# Altium schematic sheet Sheet1.SchDoc
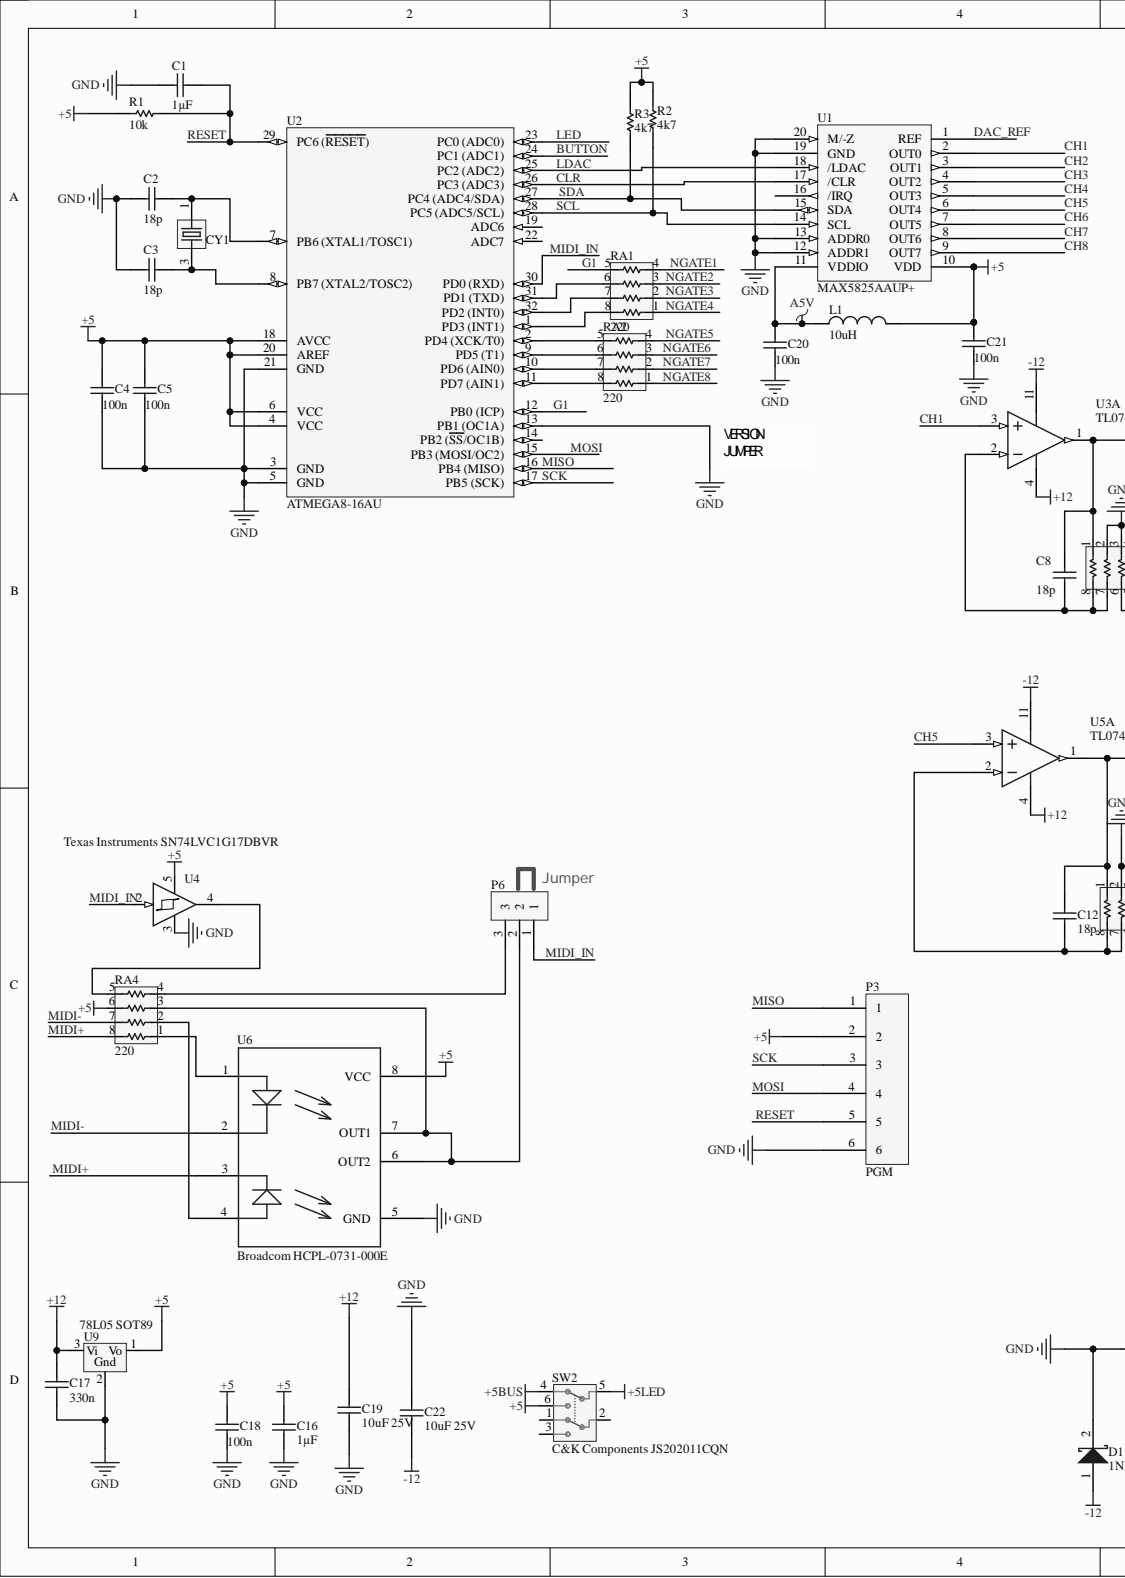
[diagram p0: Sheet1.SchDoc, left]
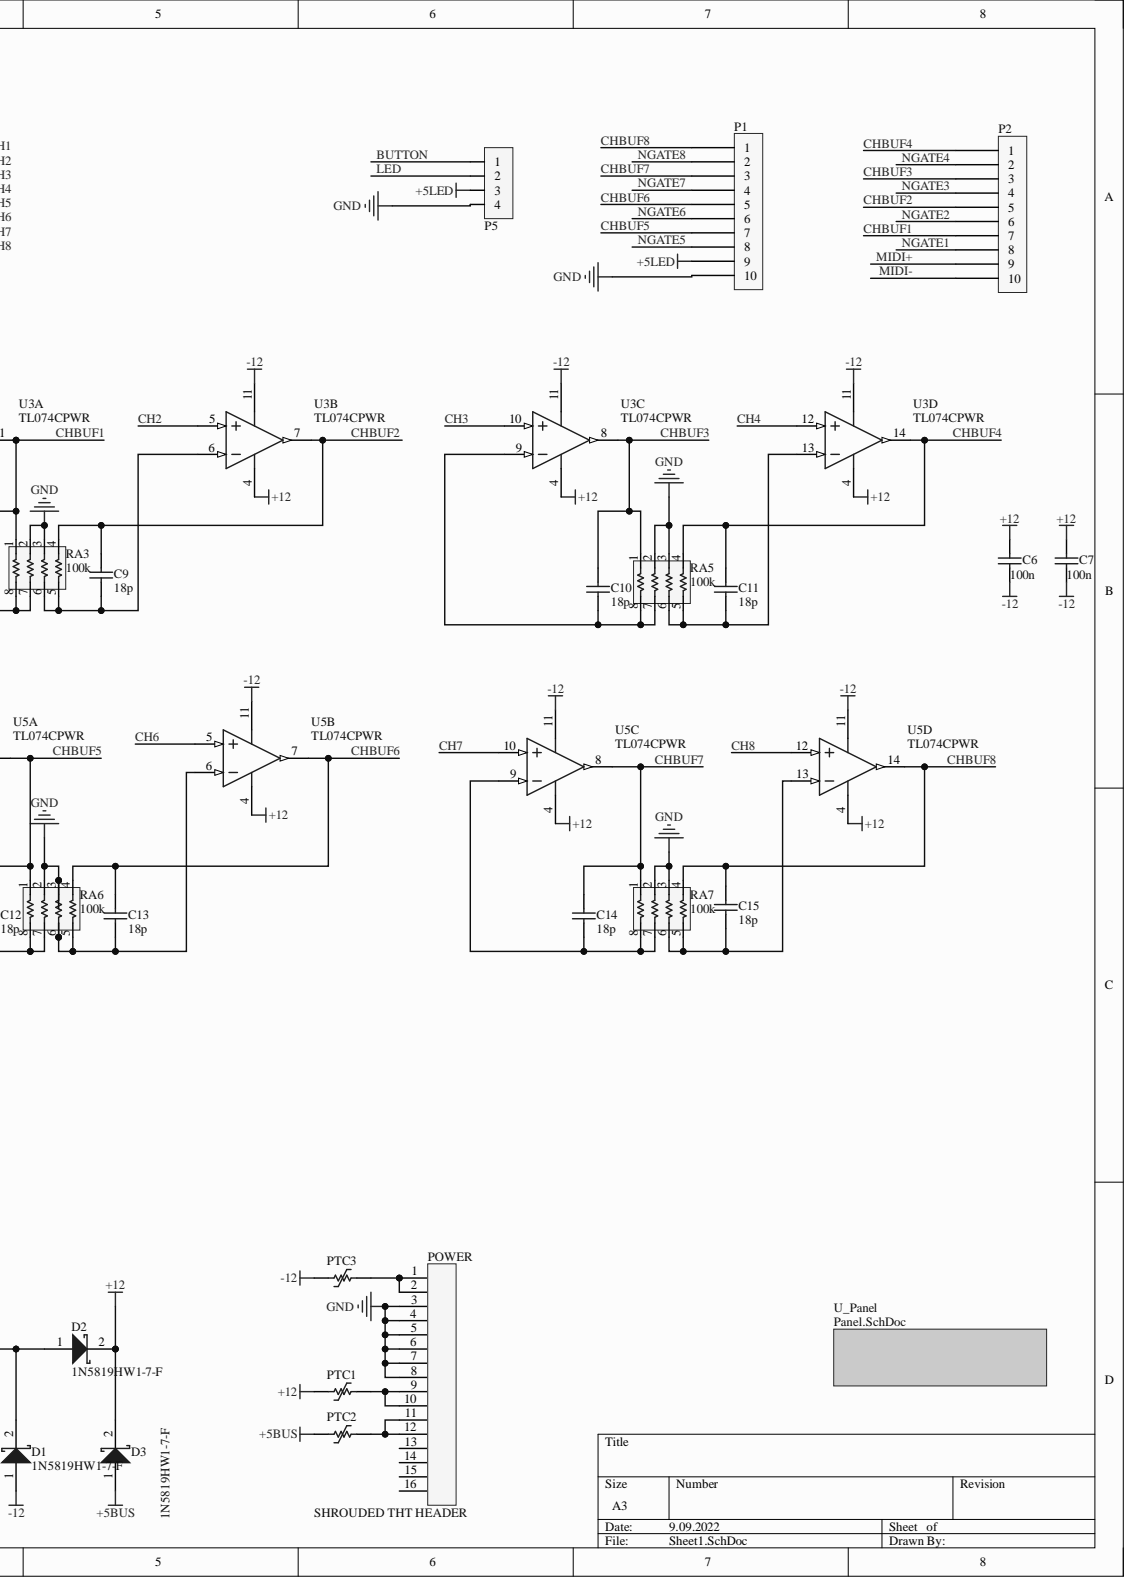
[diagram p1: Sheet1.SchDoc, right]

## Parts
C1  1µF  [CAPC1608X90X35ML10T15]
C2  18p  [CAPC1608X90X35ML10T15]
C3  18p  [CAPC1608X90X35ML10T15]
C4  100n  [CAPC1608X90X35ML10T15]
C5  100n  [CAPC1608X90X35ML10T15]
C6  100n  [CAPC1608X90X35ML10T15]
C7  100n  [CAPC1608X90X35ML10T15]
C8  18p  [CAPC1608X90X35ML10T15]
C9  18p  [CAPC1608X90X35ML10T15]
C10  18p  [CAPC1608X90X35ML10T15]
C11  18p  [CAPC1608X90X35ML10T15]
C12  18p  [CAPC1608X90X35ML10T15]
C13  18p  [CAPC1608X90X35ML10T15]
C14  18p  [CAPC1608X90X35ML10T15]
C15  18p  [CAPC1608X90X35ML10T15]
C16  1µF  [CAPC1608X90X35ML10T15]
C17  330n  [CAPC1608X90X35ML10T15]
C18  100n  [CAPC1608X90X35ML10T15]
C19  10uF 25V  [PCB-0002-00482-3]
C20  100n  [CAPC1608X90X35ML10T15]
C21  100n  [CAPC1608X90X35ML10T15]
C22  10uF 25V  [PCB-0002-00482-3]
CY1  Abracon ABM8G-16.000MHZ-4Y-T3  [PCB-twm83f3okejsqp61kejt-1]  (value not drawn)
D1  1N5819HW1-7-F  [SOD123F]
D2  1N5819HW1-7-F  [SOD123F]
D3  1N5819HW1-7-F  [SOD123F]
L1  10uH  [PCB-sg46dcudmto2bbepxwmm-1]
P1  TE Connectivity / AMP 1-87224-0  [PCB-cp1yv2tkluzxbj61re20-1]  (value not drawn)
P2  TE Connectivity / AMP 1-87224-0  [PCB-cp1yv2tkluzxbj61re20-1]  (value not drawn)
P3  PGM  [PCB-bqk69edvkj14uqp43gk0-1]
P5  FCI 68001-104HLF  [PCB-90ku6kdjhfi8i1gkzcxx-1]  (value not drawn)
P6  TE Connectivity 826629-3  [PCB-hdyvs4k31jnr0l9k2ks5-1]  (value not drawn)
POWER  SHROUDED THT HEADER  [PCB-k58dymiutsbg6tn8hs5t-1]
PTC1  PTC FUSE  [PCB-g6xbbgrf1j6f6hdcs2hx-1]  (value not drawn)
PTC2  PTC FUSE  [PCB-g6xbbgrf1j6f6hdcs2hx-1]  (value not drawn)
PTC3  PTC FUSE  [PCB-g6xbbgrf1j6f6hdcs2hx-1]  (value not drawn)
R1  10k  [RESC1609X55X30ML10T20]
R2  4k7  [RESC1609X55X30ML10T20]
R3  4k7  [RESC1609X55X30ML10T20]
RA1  220  [BOUR-CAY16-J4_V]
RA2  220  [BOUR-CAY16-J4_V]
RA3  100k  [BOUR-CAY16-J4_V]
RA4  220  [BOUR-CAY16-J4_V]
RA5  100k  [BOUR-CAY16-J4_V]
RA6  100k  [BOUR-CAY16-J4_V]
RA7  100k  [BOUR-CAY16-J4_V]
SW2  C&K Components JS202011CQN  [PCB-iaw2ery1xcz1khkintlh-1]
U1  MAX5825AAUP+  [TSOP65P640X110-20N]
U2  ATMEGA8-16AU  [PCB-yj7dx8a2gm3oo8law51k-1]
U3  TL074CPWR  [TI-PW14_L]  (parts A,B,C,D)
U4  Texas Instruments SN74LVC1G17DBVR  Manufacturer Part Number: SN74LVC1G17DBVR  [PCB-6k6ps1k1t5bfe242y65z-1]
U5  TL074CPWR  [TI-PW14_L]  (parts A,B,C,D)
U6  Broadcom HCPL-0731-000E  Manufacturer Part Number: HCPL-0731-000E  [PCB-2wkyh02oi0qh32dobx23-1]
U9  78L05 SOT89  [PCB-19361511-1]

## Nets
+5: C4.2 C5.2 C16.1 C18.2 C21.2 L1.2 P3.2 R1.2 R2.1 R3.1 RA4.6 SW2.6 U1.10(VDD) U2.4(VCC) U2.6(VCC) U2.18(AVCC) U2.20(AREF) U4.5 U6.8(VCC) U9.1
+5BUS: D3.1 PTC2.1 SW2.4
+5LED: P1.9 P5.3 SW2.5
+12: C6.2 C7.2 C17.2 C19.2 D2.2 D3.2 PTC1.1 U3.4 U5.4 U9.3
-12: C6.1 C7.1 C22.1 D1.1 PTC3.1 U3.11 U5.11
A5V: C20.2 L1.1 U1.11(VDDIO)
GND: C1.2 C2.1 C3.1 C4.1 C5.1 C16.2 C17.1 C18.1 C19.1 C20.1 C21.1 C22.2 CY1.2 CY1.4 D1.2 D2.1 P1.10 P3.6 P5.4 POWER.3 POWER.4 POWER.5 POWER.6 POWER.7 POWER.8 RA3.2 RA3.3 RA5.2 RA5.3 RA6.2 RA6.3 RA7.2 RA7.3 U1.12(ADDR1) U1.13(ADDR0) U1.19(GND) U1.20(M/-Z) U2.3(GND) U2.5(GND) U2.13(PB1_(OC1A)) U2.21(GND) U4.3 U6.5(GND) U9.2
BUTTON: P5.1 U2.24(PC1_(ADC1))
CH1: U1.2(OUT0) U3.3
CH2: U1.3(OUT1) U3.5
CH3: U1.4(OUT2) U3.10
CH4: U1.5(OUT3) U3.12
CH5: U1.6(OUT4) U5.3
CH6: U1.7(OUT5) U5.5
CH7: U1.8(OUT6) U5.10
CH8: U1.9(OUT7) U5.12
CHBUF1: C8.1 P2.7 RA3.1 U3.1
CHBUF2: C9.1 P2.5 RA3.4 U3.7
CHBUF3: C10.1 P2.3 RA5.1 U3.8
CHBUF4: C11.1 P2.1 RA5.4 U3.14
CHBUF5: C12.1 P1.7 RA6.1 U5.1
CHBUF6: C13.1 P1.5 RA6.4 U5.7
CHBUF7: C14.1 P1.3 RA7.1 U5.8
CHBUF8: C15.1 P1.1 RA7.4 U5.14
CLR: U1.17(/CLR) U2.26(PC3_(ADC3))
DAC_REF: U1.1(REF)
G1: RA1.5 U2.12(PB0_(ICP))
LDAC: U1.18(/LDAC) U2.25(PC2_(ADC2))
LED: P5.2 U2.23(PC0_(ADC0))
MIDI+: P2.9 RA4.8 U6.3
MIDI-: P2.10 RA4.7 U6.2
MIDI_IN: P6.1 U2.30(PD0_(RXD)) U4.2
MISO: P3.1 U2.16(PB4_(MISO))
MOSI: P3.4 U2.15(PB3_(MOSI/OC2))
NGATE1: P2.8 RA1.4
NGATE2: P2.6 RA1.3
NGATE3: P2.4 RA1.2
NGATE4: P2.2 RA1.1
NGATE5: P1.8 RA2.4
NGATE6: P1.6 RA2.3
NGATE7: P1.4 RA2.2
NGATE8: P1.2 RA2.1
RESET: C1.1 P3.5 R1.1 U2.29(PC6_(RESET))
SCK: P3.3 U2.17(PB5_(SCK))
SCL: R2.2 U1.14(SCL) U2.28(PC5_(ADC5/SCL))
SDA: R3.2 U1.15(SDA) U2.27(PC4_(ADC4/SDA))
NetC2_2: C2.2 CY1.1 U2.7(PB6_(XTAL1/TOSC1))
NetC3_2: C3.2 CY1.3 U2.8(PB7_(XTAL2/TOSC2))
NetC8_2: C8.2 RA3.7 RA3.8 U3.2
NetC9_2: C9.2 RA3.5 RA3.6 U3.6
NetC10_2: C10.2 RA5.7 RA5.8 U3.9
NetC11_2: C11.2 RA5.5 RA5.6 U3.13
NetC12_2: C12.2 RA6.7 RA6.8 U5.2
NetC13_2: C13.2 RA6.5 RA6.6 U5.6
NetC14_2: C14.2 RA7.7 RA7.8 U5.9
NetC15_2: C15.2 RA7.5 RA7.6 U5.13
NetP6_2: P6.2 RA4.3 U6.6(OUT2) U6.7(OUT1)
NetP6_3: P6.3 RA4.4
NetPOWER_1: POWER.1 POWER.2 PTC3.2
NetPOWER_9: POWER.9 POWER.10 PTC1.2
NetPOWER_11: POWER.11 POWER.12 PTC2.2
NetRA1_6: RA1.6 U2.31(PD1_(TXD))
NetRA1_7: RA1.7 U2.32(PD2_(INT0))
NetRA1_8: RA1.8 U2.1(PD3_(INT1))
NetRA2_5: RA2.5 U2.2(PD4_(XCK/T0))
NetRA2_6: RA2.6 U2.9(PD5_(T1))
NetRA2_7: RA2.7 U2.10(PD6_(AIN0))
NetRA2_8: RA2.8 U2.11(PD7_(AIN1))
NetRA4_1: RA4.1 U6.1
NetRA4_2: RA4.2 U6.4
NetRA4_5: RA4.5 U4.4

Not connected: POWER 13 14 15 16; SW2 1 2 3; U1 16(/IRQ); U2 14(PB2_(SS/OC1B)) 19(ADC6) 22(ADC7)

## Library data of the parts
C1-C18 C20 C21 = CMP-19299715-3: CAP CER 16V 10% X7R 0603; Case/Package (SI): 1608; Lead-Free Status: Lead Free; Voltage Rating (DC): 16.0 V; Capacitance Tolerance: ±10%; Component Kind: Standard; Dielectric Characteristic: X7R; Library Name: CommunityVault; Lifecycle Status: Active; Mounting Style: Surface Mount; Number of Pins: 2; Octopart Url: https://octopart.com/grm188r71c334ka01d-murata-196913; OctopartID: e6082f01c25f39cf; Packaging: Tape & Reel (TR); REACH SVHC Compliance: No SVHC; RoHS: Compliant; Size-Height: 0.0008 m; Size-Length: 0.0016 m; Size-Thickness: 0.0008 m; Size-Width: 0.0008 m
  C1 C16: Capacitance: 1µF
  C2 C3 C8-C15: Capacitance: 18p
  C4-C7 C18 C20 C21: Capacitance: 100n
  C17: Capacitance: 330n
C19 C22 = CMP-121636-9: CAP CER 10uF 25V 10% X7R 0805; Manufacturer Part Number: GRM21BR71H105KA12L; Case/Package (SI): 2012; Dielectric Material: Ceramic Multilayer; Lead-Free Status: Lead Free; Voltage Rating (DC): 50.0 V; Capacitance: 10uF 25V; Capacitance Tolerance: ±10%; Case/Package: 0805; Component Kind: Standard; Dielectric Characteristic: X7R; Library Name: My Personal Space; Lifecycle Status: Active; Mounting Style: Surface Mount; Number of Pins: 2; Octopart Url: https://octopart.com/grm21br71h105ka12l-murata-601372; OctopartID: 7ee5b7e6769fa122; REACH SVHC Compliance: No SVHC; RoHS: Compliant; Size-Height: 0.00125 m; Size-Length: 0.002 m; Size-Thickness: 0.00125 m; Size-Width: 0.00125 m
CY1 = CMP-1d0ad530b882c2cd-3: Chrystal 16MHz 20ppm 18pF 3.2x2.5; Frequency: 16.0 MHz; Lead-Free Status: Lead Free; Load Capacitance: 10.0 pF; Component Kind: Standard; Library Name: CommunityVault; Manufacturer Part Number: ABM8G-16.000MHZ-4Y-T3; Number of Pins: 4; Octopart URL: http://octopart.com/abm8g-16.000mhz-4y-t3-abracon-19955950; OctopartID: 1d0ad530b882c2cd; RoHS: Compliant
D1-D3 = CMP-f1953c9df3432e52-4: 1N5819 Schottky Diode 40V 1A SOD123F; Component Kind: Standard; Library Name: CommunityVault
L1 = CMP-6a1da3ae1fb2b5da-1: Surface Mount High Frequency Inductor, LQM21FN Series, 10 H, 20%, 0805 [2012 Metric], 15 MHz; Case/Package (SI): 2012; Component Kind: Standard; Library Name: CommunityVault
P1 P2 = CMP-ea6c213c28e935ab-9: Connector Unshrouded Header 10 Position 2.54mm Solder Straight Thru-Hole; ELV Compliant: Compliant; Gender: Male; Lead-Free Status: Lead Free; Mounting Angle: 180 °; Number of Contacts: 10; Color: Black; Component Kind: Standard; Contact Material: Phosphor Bronze; Housing Color: Black; Library Name: CommunityVault; Mounting Style: PCB; Number of Positions: 10; Octopart URL: http://octopart.com/1-87224-0-te+connectivity+%2F+amp-39512035; OctopartID: ea6c213c28e935ab; Orientation: Vertical; RoHS: Compliant; Size-Height: 2.29 mm; Size-Length: 25.0 mm
P3 = CMP-f0413c77462d2f86-1: REDFIT IDC SKEDD Connector WR-WST, pitch 2.54 mm, 6 pins 490107670612; Current Rating: 1.00 A; Component Kind: Standard; Library Name: CommunityVault; Mounting Style: Plug In
P5 = CMP-d8b113d69cb60776-9: Conn; Rect; Header; Unshrouded; 4 Pos; 2.54mm Pitch; 1-Row; Thru-Hole; BergStik; Lead-Free Status: Lead Free; Component Kind: Standard; Library Name: CommunityVault; Mounting Style: Through Hole
P6 = CMP-6565ae111eb8baa2-1: Header HDR 3 POS 2.54mm; Number of Contacts: 3; Color: Green; Component Kind: Standard; Housing Color: Green; Library Name: CommunityVault; Packaging: Box
POWER = CMP-7df8b4f188efa23f-3: 16-Circuits Dual Row Vertical c-Grid Header Tin Pc Tail Plating; Manufacturer Part Number: 70246-1601; Current Rating: 2.50 A; ELV Compliant: Compliant; Gender: Male; Glow Wire Compliant: Non-Compliant; Insulation Resistance: 12.0 GΩ; Lead-Free Status: Lead Free; Number of Circuits: 16; Pin Pitch: 2.54 mm; Voltage Rating (DC): 250 V; Color: Black; Component Kind: Standard; Contact Material: Phosphor Bronze; Contact Plating: Gold; Flammability Rating: UL 94 V-0; Halogen Free Status: Not Low Halogen; Housing Color: Black; Housing Material: Polyester; Library Name: CommunityVault; Lifecycle Status: Active; Mounting Style: Through Hole, Vertical; Number of Contacts: 16; Number of Positions: 16; Number of Rows: 2; Octopart URL: http://octopart.com/70246-1601-molex-249969; OctopartID: 7df8b4f188efa23f; Orientation: Vertical; Packaging: Tray; REACH SVHC Compliance: No SVHC; RoHS: Compliant; Size-Height: 9.27 mm; Size-Length: 27.9 mm; Size-Width: 8.89 mm
PTC1-PTC3 = CMP-07f4cf3e8fc9d833-1: PTC Resettable Fuse 0.05A(hold) 0.15A(trip) 60VDC 10A 0.4W 1.5s 3.6Ohm SMD Solder Pad 3.5 X 1.8 X 0.85mm T/R; Manufacturer Part Number: 0ZCJ0005FF2E; Lead-Free Status: Lead Free; Component Kind: Standard; Library Name: My Personal Space; Octopart URL: http://octopart.com/0zcj0005ff2e-bel+fuse-29404507; OctopartID: 07f4cf3e8fc9d833; RoHS: Compliant
R1 = CMP-1863112-2: RES SMD 1K OHM 0.1% 1/10W 0603; Lead-Free Status: Lead Free; Power Rating: 0.1 W; Resistance Tolerance: ±1%; Voltage Rating (DC): 75.0 V; Case/Package: 0603; Component Kind: Standard; Library Name: CommunityVault; Octopart Url: https://octopart.com/tnpw0603100kbeea-vishay-42335327; OctopartID: c79f0c101ea61858; REACH SVHC Compliance: No SVHC; Resistance: 10k; RoHS: Compliant
R2 R3 = CMP-1863112-2: RES SMD 49.9K OHM 0.1% 1/10W 0603; Lead-Free Status: Lead Free; Power Rating: 0.1 W; Resistance Tolerance: ±1%; Voltage Rating (DC): 75.0 V; Case/Package: 0603; Component Kind: Standard; Library Name: CommunityVault; Octopart Url: https://octopart.com/tnpw0603100kbeea-vishay-42335327; OctopartID: c79f0c101ea61858; REACH SVHC Compliance: No SVHC; Resistance: 4k7; RoHS: Compliant
RA1-RA7 = CMP-1150485-9: Chip Resistor Array, 8-Pin SMD 1206; Manufacturer Part Number: CAY16-103J4LF; Case/Package (SI): 3216; Lead-Free Status: Lead Free; Number of Circuits: 4; Number of Contacts: 8; Power Rating: 250 mW; Resistance Tolerance: ±5%; Voltage Rating (DC): 25.0 V; Case/Package: 1206; Component Kind: Standard; ComponentLink1Description: Manufacturer URL; ComponentLink1URL: http://www.bourns.com/; ComponentLink2Description: Datasheet; ComponentLink2URL: http://www.bourns.com/docs/Product-Datasheets/CATCAY.pdf; DatasheetVersion: Jan-15; Library Name: CommunityVault; Mounting Style: Surface Mount; Number of Pins: 8; Octopart Url: http://octopart.com/cay16-103j4lf-bourns-152968; OctopartID: c21e0b16b2f01dd9; PackageDescription: 8-Pin Surface Mount Device, Body 1.6 x 3.2 mm, Pitch 0.8 mm; PackageReference: CAY16-J4; Packaging: Cut Tape (CT); REACH SVHC Compliance: No SVHC; RoHS: Compliant; Seller Landing  Url: https://octopart.com/click/track?country=US&ak=8157f166&sig=0201b94&sid=1106&ppid=152968&vpid=14551898&ct=offers; Size-Height: 500 µm; Size-Length: 3.20 mm; Size-Width: 1.60 mm
  RA1 RA2 RA4: Resistance: 220
  RA3 RA5-RA7: Resistance: 100k
SW2 = CMP-0b36c2500016d4f1-13: Switch; Slide; DPDT; ON-ON; Top Slide; 300mA; 6VDC; ThruHole; PC Pin; Lead-Free Status: Lead Free; Component Kind: Standard; Contacts Type: DPDT; Library Name: My Personal Space; Manufacturer Part Number: JS202011CQN; Mounting Style: Through Hole, PC Board; Octopart URL: https://octopart.com/js202011cqn-c%26k+components-7089734; OctopartID: 0b36c2500016d4f1; Orientation: Vertical; Packaging: Bulk; REACH SVHC Compliance: No SVHC; RoHS: Compliant
U1 = CMP-4a8282cd273b9545-4: IC DAC 12BIT I2C/SRL 20TSSOP; Manufacturer: Maxim Integrated; Component Kind: Standard; Digikey_PN: MAX5825AAUP+-ND; Library Name: CommunityVault; Lifecycle Status: Not Listed by Manufacturer; Manufacturer Part Number: MAX5825AAUP+; Octopart URL: http://octopart.com/max5825aaup%2B-maxim+integrated-21317855; OctopartID: 4a8282cd273b9545; Package: TSSOP20; RoHS: Compliant
U2 = CMP-973577-5: Access Time: 8.00 µs; Clock Speed: 16 MHz, 8.00 MHz (max); Lead-Free Status: Lead Free; RAM Memory Size: 1024 B; Component Kind: Standard; Description: ATMEGA8-16AU; Library Name: CommunityVault; Manufacturer Part Number: ATMEGA8-16AU
U3 U5 = CMP-10488104-3: 0.1 uV/C Drift, Quad, Low Noise, Rail-to-Rail, Zero Drift Operational Amplifier, 4 to 36 V, -40 to 105 degC, 14-pin SOP (PW14), Green (RoHS & no Sb/Br); Bandwidth: 2000000.0 Hz; Input Offset Drift: 1e-07 V/K; Lead-Free Status: Lead Free; Number of Channels: 4; Slew Rate: 0.8 V/μs; Component Kind: Standard; Gain Bandwidth Product: 2000000.0 Hz; Library Name: CommunityVault; Lifecycle Status: Active; Number of Pins: 14; Octopart Url: https://octopart.com/opa4180ipw-texas+instruments-24576007; OctopartID: 410f8972646e9ec8; REACH SVHC Compliance: No SVHC; RoHS: Compliant
U4 = CMP-19354131-7: Schmitt Trigger Buffer 1-CH Non-Inverting CMOS 5-Pin SOT-23 T/R; Lead-Free Status: Lead Free; Number of Bits: 1; Case/Package: SOT-23; Component Kind: Standard; Library Name: CommunityVault; Lifecycle Status: Active; Mounting Style: Surface Mount; OctopartID: d78d1e818124084a; Packaging: Tape & Reel (TR), Reel, Cut Tape (CT); REACH SVHC Compliance: No SVHC; RoHS: Compliant
U6 = CMP-6b2ca61b1de7b4eb-1: Optocoupler DC-IN 2-CH Darlington With Base DC-OUT 8-Pin SOIC Tube; Data Rate: 100 kbps; Forward Voltage: 1.40 V; Input Current: 12.0 mA; Isolation Voltage: 3.75 kV; Lead-Free Status: Lead Free; Number of Channels: 2; Output Voltage: 18.0 V; Case/Package: SOIC; Component Kind: Standard; Input Voltage (DC): 1.40 V; Library Name: FavoritesLibrary; Lifecycle Status: Not Listed by Manufacturer; Mounting Style: Surface Mount; Number of Circuits: 2; Number of Pins: 8; Octopart URL: https://octopart.com/hcpl-0731-000e-broadcom-71127981; OctopartID: 6b2ca61b1de7b4eb; Operating Temperature: 0.00 °C to 70.0 °C; Packaging: Tube; REACH SVHC Compliance: No SVHC; RoHS: Compliant
U9 = CMP-19361511-2: Voltage Regulator IC; Manufacturer Part Number: UA78L05CPK; Dropout Voltage: 1.7 V; Lead-Free Status: Lead Free; Number of Outputs: 1; Output Capacitor Type: Capacitor Free; Output Current: 0.1 A; Quiescent Current: 0.0038 A; Case/Package: SOT-89; Component Kind: Standard; Library Name: CommunityVault; Lifecycle Status: Active; Mounting Style: Surface Mount; Number of Pins: 3; Number of Regulated Outputs: 1; Octopart Url: https://octopart.com/ua78l05cpk-texas+instruments-525289; OctopartID: 1f72d67039fdc0b5; Packaging: Tape & Reel (TR); REACH SVHC Compliance: No SVHC; RoHS: Compliant
